# Altium schematic sheet H5_Codec.SchDoc(H5_Codec)
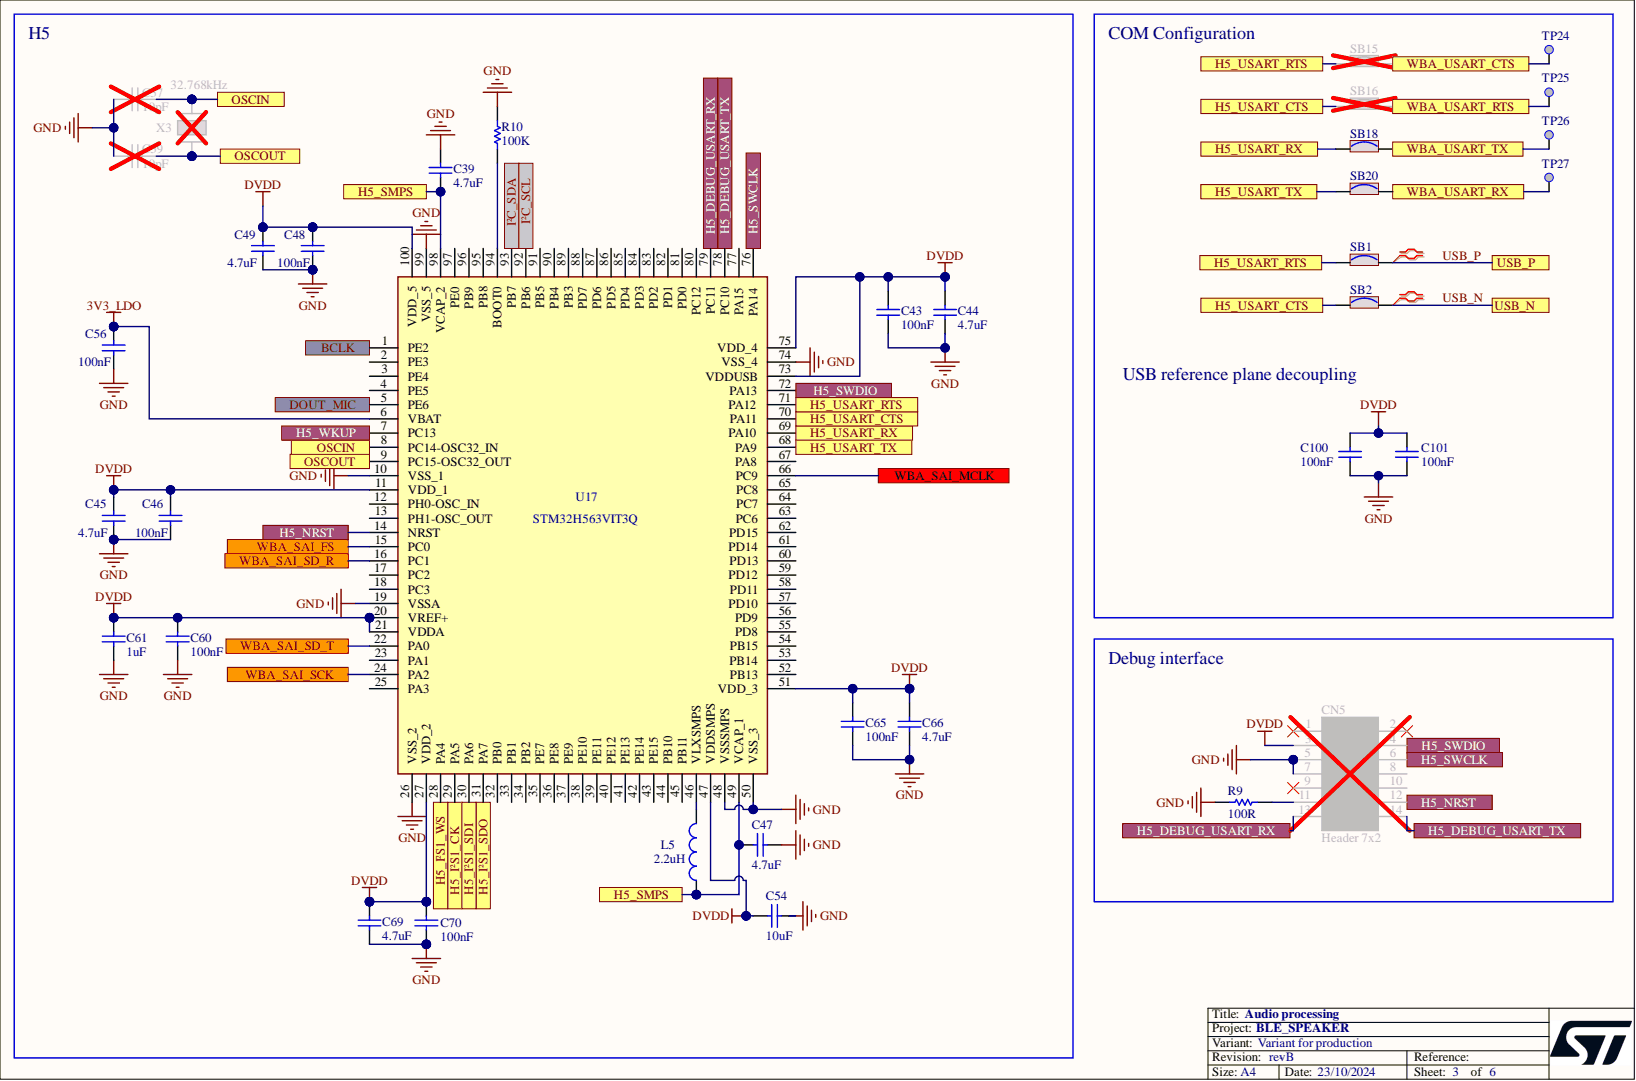
[diagram p0: H5_Codec.SchDoc]

## Parts
C39  value 4.7uF  [C0402]  (hidden comment: Capacitor)
C43  value 100nF  [C0201_H0P3]  (hidden comment: Capacitor)
C44  value 4.7uF  [C0402]  (hidden comment: Capacitor)
C45  value 4.7uF  [C0402]  (hidden comment: Capacitor)
C46  value 100nF  [C0201_H0P3]  (hidden comment: Capacitor)
C47  value 4.7uF  [C0402]  (hidden comment: Capacitor)
C48  value 100nF  [C0201_H0P3]  (hidden comment: Capacitor)
C49  value 4.7uF  [C0402]  (hidden comment: Capacitor)
C54  value 10uF  [C0402]  (hidden comment: Capacitor)
C56  value 100nF  [C0201_H0P3]  (hidden comment: Capacitor)
C57  value 10pF  [C0402]  (hidden comment: Capacitor)
C59  value 10pF  [C0402]  (hidden comment: Capacitor)
C60  value 100nF  [C0201_H0P3]  (hidden comment: Capacitor)
C61  value 1uF  [C0402]  (hidden comment: Capacitor)
C65  value 100nF  [C0201_H0P3]  (hidden comment: Capacitor)
C66  value 4.7uF  [C0402]  (hidden comment: Capacitor)
C69  value 4.7uF  [C0402]  (hidden comment: Capacitor)
C70  value 100nF  [C0201_H0P3]  (hidden comment: Capacitor)
C100  value 100nF  [C0201_H0P3]  (hidden comment: Capacitor)
C101  value 100nF  [C0201_H0P3]  (hidden comment: Capacitor)
CN5  Header 7x2  [CON_STDC14_Receiver_SMALL]
L5  value 2.2uH  [IND_2520_TOKO_DFE252012P]  (hidden comment: Inductor)
R9  value 100R  [R0402]  (hidden comment: Resistor)
R10  value 100K  [R0402]  (hidden comment: Resistor)
SB1  SB_ON  [SOLDER-BRIDGE_R0201_0R]  (value not drawn)
SB2  SB_ON  [SOLDER-BRIDGE_R0201_0R]  (value not drawn)
SB15  SB_ON  [SOLDER-BRIDGE_R0201_0R]  (value not drawn)
SB16  SB_ON  [SOLDER-BRIDGE_R0201_0R]  (value not drawn)
SB18  SB_ON  [SOLDER-BRIDGE_R0201_0R]  (value not drawn)
SB20  SB_ON  [SOLDER-BRIDGE_R0201_0R]  (value not drawn)
TP24  Test Point  [TP_SMD_1MM]  (value not drawn)  (no BOM)
TP25  Test Point  [TP_SMD_1MM]  (value not drawn)  (no BOM)
TP26  Test Point  [TP_SMD_1MM]  (value not drawn)  (no BOM)
TP27  Test Point  [TP_SMD_1MM]  (value not drawn)  (no BOM)
U17  STM32H563VIT3Q  [QFP50P1600X1600X160-100N]
X3  value 32.768kHz  [CRY_NX1610SE_2P]  (hidden comment: XTAL_2_PINS)

## Ports
BCLK, DOUT_MIC, H5_DEBUG_USART_RX, H5_DEBUG_USART_TX, H5_I²S1_CK, H5_I²S1_SDI, H5_I²S1_SDO, H5_I²S1_WS, H5_NRST, H5_SMPS, H5_SWCLK, H5_SWDIO, H5_USART_CTS, H5_USART_RTS, H5_USART_RX, H5_USART_TX, H5_WKUP, I²C_SCL, I²C_SDA, OSCIN, OSCOUT, USB_N, USB_P, WBA_SAI_FS, WBA_SAI_MCLK, WBA_SAI_SCK, WBA_SAI_SD_R, WBA_SAI_SD_T, WBA_USART_CTS, WBA_USART_RTS, WBA_USART_RX, WBA_USART_TX

## Nets
3V3_LDO: C56.1 U17.6(VBAT)
DVDD: C43.2 C44.2 C45.2 C46.2 C48.2 C49.2 C54.1 C60.2 C61.1 C65.2 C66.2 C69.2 C70.2 C100.2 C101.2 CN5.3 U17.11(VDD_1) U17.20(VREF+) U17.21(VDDA) U17.27(VDD_2) U17.47(VDDSMPS) U17.51(VDD_3) U17.73(VDDUSB) U17.75(VDD_4) U17.100(VDD_5)
GND: C39.2 C43.1 C44.1 C45.1 C46.1 C47.2 C48.1 C49.1 C54.2 C56.2 C57.2 C59.2 C60.1 C61.2 C65.1 C66.1 C69.1 C70.1 C100.1 C101.1 CN5.5 CN5.7 R9.1 R10.1 U17.10(VSS_1) U17.19(VSSA) U17.26(VSS_2) U17.48(VSSSMPS) U17.50(VSS_3) U17.74(VSS_4) U17.99(VSS_5)
BCLK: U17.1(PE2) ; port BCLK
DOUT_MIC: U17.5(PE6) ; port DOUT_MIC
H5_DEBUG_USART_RX: CN5.13 U17.79(PC11) ; port H5_DEBUG_USART_RX
H5_DEBUG_USART_TX: CN5.14 U17.78(PC10) ; port H5_DEBUG_USART_TX
H5_I²S1_CK: U17.29(PA5) ; port H5_I²S1_CK
H5_I²S1_SDI: U17.30(PA6) ; port H5_I²S1_SDI
H5_I²S1_SDO: U17.31(PA7) ; port H5_I²S1_SDO
H5_I²S1_WS: U17.28(PA4) ; port H5_I²S1_WS
H5_NRST: CN5.12 U17.14(NRST) ; port H5_NRST
H5_SMPS: C39.1 C47.1 L5.1 U17.49(VCAP_1) U17.98(VCAP_2) ; port H5_SMPS
H5_SWCLK: CN5.6 U17.76(PA14) ; port H5_SWCLK
H5_SWDIO: CN5.4 U17.72(PA13) ; port H5_SWDIO
H5_USART_CTS: SB2.2 SB16.2 U17.70(PA11) ; port H5_USART_CTS
H5_USART_RTS: SB1.2 SB15.2 U17.71(PA12) ; port H5_USART_RTS
H5_USART_RX: SB18.2 U17.69(PA10) ; port H5_USART_RX
H5_USART_TX: SB20.2 U17.68(PA9) ; port H5_USART_TX
H5_WKUP: U17.7(PC13) ; port H5_WKUP
I²C_SCL: U17.92(PB6) ; port I²C_SCL
I²C_SDA: U17.93(PB7) ; port I²C_SDA
OSCIN: C57.1 U17.8(PC14-OSC32_IN) X3.1 ; port OSCIN
OSCOUT: C59.1 U17.9(PC15-OSC32_OUT) X3.2 ; port OSCOUT
USB_N: SB2.1 ; port USB_N
USB_P: SB1.1 ; port USB_P
WBA_SAI_FS: U17.15(PC0) ; port WBA_SAI_FS
WBA_SAI_MCLK: U17.66(PC9) ; port WBA_SAI_MCLK
WBA_SAI_SCK: U17.24(PA2) ; port WBA_SAI_SCK
WBA_SAI_SD_R: U17.16(PC1) ; port WBA_SAI_SD_R
WBA_SAI_SD_T: U17.22(PA0) ; port WBA_SAI_SD_T
WBA_USART_CTS: SB15.1 TP24.1 ; port WBA_USART_CTS
WBA_USART_RTS: SB16.1 TP25.1 ; port WBA_USART_RTS
WBA_USART_RX: SB20.1 TP27.1 ; port WBA_USART_RX
WBA_USART_TX: SB18.1 TP26.1 ; port WBA_USART_TX
NetCN5_11: CN5.11 R9.2
NetL5_2: L5.2 U17.46(VLXSMPS)
NetR10_2: R10.2 U17.94(BOOT0)

Not connected: CN5 1 2 8 9 10; U17 2(PE3) 3(PE4) 4(PE5) 12(PH0-OSC_IN) 13(PH1-OSC_OUT) 17(PC2) 18(PC3) 23(PA1) 25(PA3) 32(PB0) 33(PB1) 34(PB2) 35(PE7) 36(PE8) 37(PE9) 38(PE10) 39(PE11) 40(PE12) 41(PE13) 42(PE14) 43(PE15) 44(PB10) 45(PB11) 52(PB13) 53(PB14) 54(PB15) 55(PD8) 56(PD9) 57(PD10) 58(PD11) 59(PD12) 60(PD13) 61(PD14) 62(PD15) 63(PC6) 64(PC7) 65(PC8) 67(PA8) 77(PA15) 80(PC12) 81(PD0) 82(PD1) 83(PD2) 84(PD3) 85(PD4) 86(PD5) 87(PD6) 88(PD7) 89(PB3) 90(PB4) 91(PB5) 95(PB8) 96(PB9) 97(PE0)

## Library data of the parts
C39 C44 C45 C47 C49 C66 C69 = MCD-01356: Capacitor, MLCC, 4.7uF, 6V3, X5R, +/-20%, 0402, T=0.5mm; Manufacturer: MURATA; Manufacturer_Part_Number: GRM155R60J475ME47; Part_Preference: Recommended; Component_Country_Of_Origin: Japan°°; Database Table Name: MCD_PARTS$; ID: 3456; Order_Code_RS: 814-5230; ShowFields: YES; Component Kind: Standard; ComponentLink1URL: http://tunmcdsvn.tun.st.com/Component_Documentation_Library/Trunk/Capacitor/MURATA_GRM_Series; Internal_Part_Number: MCD-01356; Library Name: MCD_PARTS_database.DbLib; Order_Code_Digikey: 490-5915-1-ND; Order_Code_Farnell: 2666407; Package: 0402; Part_Type: Capacitor; Status: Released
C43 C46 C48 C56 C60 C65 C70 C100 C101 = MCD-00697: Capacitor, MLCC, 100nF,10V, X5R, +/-10%, 0201, T=0.3mm; Manufacturer: TDK; Manufacturer_Part_Number: C0603X5R1A104K030BC; Part_Preference: Recommended; Component_Country_Of_Origin: Unknown; Database Table Name: MCD_PARTS$; ID: 3046; ShowFields: YES; Component Kind: Standard; ComponentLink1URL: http://tunmcdsvn.tun.st.com/Component_Documentation_Library/Trunk/Capacitor/TDK_MCC_Series; Internal_Part_Number: MCD-00697; Library Name: MCD_PARTS_database.DbLib; Order_Code_Digikey: 445-7318-1-ND; Order_Code_Farnell: 2524957; Order_Code_RS: N/A; Package: 0201; Part_Type: Capacitor; Status: Released
C54 = MCD-03140: Capacitor, MLCC, 10uF, 6.3V, X5R, +/-20%, 0402, T=0.5mm; Manufacturer: MURATA; Manufacturer_Part_Number: GRM155R60J106ME05D; Part_Preference: Recommended; Component_Country_Of_Origin: China, Japan; Database Table Name: MCD_PARTS$; ID: 5256; ShowFields: YES; Component Kind: Standard; ComponentLink1URL: http://tunmcdsvn.tun.st.com/Component_Documentation_Library/Trunk/Capacitor/MURATA_GRM_Series; Internal_Part_Number: MCD-03140; Library Name: MCD_PARTS_database.DbLib; Order_Code_Digikey: 490-GRM155R60J106ME05DTR-ND; Order_Code_Farnell: 3784428; Order_Code_RS: N/A; Package: 0402; Part_Type: Capacitor; Status: Released
C57 C59 = MCD-00015: Capacitor, MLCC, 10pF, 50V, NPO, +/-5%, 0402, T=0.5mm; Manufacturer: YAGEO; Manufacturer_Part_Number: CC0402JRNPO9BN100; Part_Preference: Recommended; Component_Country_Of_Origin: China; Database Table Name: MCD_PARTS$; ID: 2465; Order_Code_RS: 3019160RL; ShowFields: YES; Component Kind: Standard; ComponentLink1URL: http://tunmcdsvn.tun.st.com/Component_Documentation_Library/Trunk/Capacitor/YAGEO_NP0_Series; Internal_Part_Number: MCD-00015; Library Name: MCD_PARTS_database.DbLib; Order_Code_Digikey: 311-1014-1-ND; Order_Code_Farnell: 3019160RL; Package: 0402; Part_Type: Capacitor; Status: Released
C61 = MCD-01722: Capacitor, MLCC, 1uF, 10V, X5R, +/-10%, 0402, T=0.5mm; Manufacturer: YAGEO; Manufacturer_Part_Number: CC0402KRX5R6BB105; Part_Preference: Recommended; Component_Country_Of_Origin: China; Database Table Name: MCD_PARTS$; ID: 3822; ShowFields: YES; Component Kind: Standard; ComponentLink1URL: http://tunmcdsvn.tun.st.com/Component_Documentation_Library/Trunk/Capacitor/YAGEO_X5R_Series; Internal_Part_Number: MCD-01722; Library Name: MCD_PARTS_database.DbLib; Order_Code_Digikey: 311-1439-1-ND; Order_Code_Farnell: 1458896; Order_Code_RS: N/A; Package: 0402; Part_Type: Capacitor; Status: Released
CN5 = MCD-00639: Header, 7x2, 1.27mm, VR, SMD, T=6.12mm; Manufacturer: SAMTEC; Manufacturer_Part_Number: FTSH-107-01-L-DV-K; Part_Preference: Recommended; Part_Type: Connector; Partial_Alternate_Internal_Part_Number: MCD-03872; Component_Country_Of_Origin: China, Costa Rica, Malaysia, USA, Vietnam; Database Table Name: MCD_PARTS$; ID: 3107; Order_Code_RS: 179-8765; ShowFields: YES; Component Kind: Standard; ComponentLink1URL: http://tunmcdsvn.tun.st.com/Component_Documentation_Library/Trunk/Connector/SAMTEC_FTSH_Series/; Internal_Part_Number: MCD-00639; Library Name: MCD_PARTS_database.DbLib; Order_Code_Digikey: SAM9449-ND; Order_Code_Farnell: 2856443; Status: Released; Value: Header 7x2
L5 = MCD-00161: Inductor, 2.2uH, +/-20%, 2,2A, 84mR, 1008, T=1.2mm; Manufacturer: TOKO; Manufacturer_Part_Number: DFE252012P-2R2M=P2; Part_Preference: Recommended; Component_Country_Of_Origin: Japan°°; Database Table Name: MCD_PARTS$; ID: 2711; Order_Code_RS: 167-0606; ShowFields: YES; Component Kind: Standard; ComponentLink1URL: http://tunmcdsvn.tun.st.com/Component_Documentation_Library/Trunk/Inductor/TOKO_DFE252012P_Series; Internal_Part_Number: MCD-00161; Library Name: MCD_PARTS_database.DbLib; Order_Code_Digikey: 490-10649-1-ND; Order_Code_Farnell: 2530074; Package: 1008; Part_Type: Inductor; Status: Checked
R9 = MCD-00119: Resistor, 100R, 0402, +/-1%, 1/16W; Manufacturer: YAGEO; Manufacturer_Part_Number: RC0402FR-07100RL; Part_Preference: Not recommended; Component_Country_Of_Origin: China; Database Table Name: MCD_PARTS$; ID: 2748; ShowFields: YES; Component Kind: Standard; ComponentLink1URL: http://tunmcdsvn.tun.st.com/Component_Documentation_Library/Trunk/Resistor/YAGEO_RC_L_Series; Internal_Part_Number: MCD-00119; Library Name: MCD_PARTS_database.DbLib; Order_Code_Digikey: 311-100LRCT-ND; Order_Code_Farnell: 9239111; Order_Code_RS: N/A; Package: 0402; Part_Type: Resistor; Status: Released
R10 = MCD-00059: Resistor, 100K, 0402, +/-1%, 1/16W; Manufacturer: YAGEO; Manufacturer_Part_Number: RC0402FR-07100KL; Part_Preference: Recommended; Partial_Alternate_Internal_Part_Number: MCD-03301; Component_Country_Of_Origin: China; Database Table Name: MCD_PARTS$; ID: 2533; Order_Code_RS: 2421891; ShowFields: YES; Component Kind: Standard; ComponentLink1URL: http://tunmcdsvn.tun.st.com/Component_Documentation_Library/Trunk/Resistor/YAGEO_RC_L_Series; Internal_Part_Number: MCD-00059; Library Name: MCD_PARTS_database.DbLib; Order_Code_Digikey: 311-100KLRTR-ND; Order_Code_Farnell: 2421891; Package: 0402; Part_Type: Resistor; Status: Released
SB1 SB2 SB15 SB16 SB18 SB20 = MCD-03219: Solder Bridge ON, 0R, 0201, 1/20W; Manufacturer: YAGEO; Manufacturer_Part_Number: RC0201JR-070RL; Part_Preference: Recommended; Part_Type: Misc; Component_Country_Of_Origin: China; Database Table Name: MCD_PARTS$; ID: 5335; Order_Code_RS: 198-7623; ShowFields: YES; Component Kind: Standard; ComponentLink1URL: http://tunmcdsvn.tun.st.com/Component_Documentation_Library/Trunk/Resistor/YAGEO_RC_L_Series; Internal_Part_Number: MCD-03219; Library Name: MCD_PARTS_database.DbLib; Order_Code_Digikey: 311-0.0NTR-ND; Order_Code_Farnell: 1458895; Status: Checked; Value: 0R
  SB1: Package: 0201
  SB2 SB15 SB16 SB18 SB20: Package: SB0201
TP24-TP27 = MCD-00074: Test Point 1mm; Part_Preference: Recommended; Part_Type: Misc; Component_Country_Of_Origin: Unknown; Database Table Name: MCD_PARTS$; ID: 2518; ShowFields: YES; ComponentLink1URL: http://tunmcdsvn.tun.st.com/Component_Documentation_Library/Trunk/Misc/; Internal_Part_Number: MCD-00074; Library Name: MCD_PARTS_database.DbLib; Manufacturer: N/A; Manufacturer_HQ_COO: Unknown; Manufacturer_Part_Number: N/A; Order_Code_Digikey: N/A; Order_Code_Farnell: N/A; Order_Code_RS: N/A; Status: Released; Value: Test Point 1mm
U17 = STM32H563VIT3Q: ARM Microcontrollers - MCU Arm Cortex-M33 32-bit 250 MHz 2 Mbytes Flash 640KB RAM I3C, DCMI, Ethernet, SMPS; Manufacturer_Name: STMicroelectronics; Geometry.Height: 1.6mm; Component Kind: Standard; Datasheet Link: https://www.st.com/resource/en/datasheet/stm32h562ag.pdf; Library Name: STM32H563VIT3Q.SchLib; Manufacturer_Part_Number: STM32H563VIT3Q; Mouser Part Number: 511-STM32H563VIT3Q; Mouser Price/Stock: https://www.mouser.co.uk/ProductDetail/STMicroelectronics/STM32H563VIT3Q?qs=amGC7iS6iy8I0QD381YFuQ%3D%3D
X3 = MCD-02291: Crystal, 32.768kHz, 9pF, +/-20ppm, 70KR, 1.6x1mm; Manufacturer: NDK; Manufacturer_Part_Number: NX1610SE-32.768KHZ-EXS00A-MU01499; Part_Preference: Recommended; Part_Type: Crystal_Osc; Component_Country_Of_Origin: Unknown; Database Table Name: MCD_PARTS$; ID: 4391; ShowFields: YES; Component Kind: Standard; ComponentLink1URL: http://tunmcdsvn.tun.st.com/Component_Documentation_Library/Trunk/Crystal_Oscillator/NDK_NX1610SE_Series; Internal_Part_Number: MCD-02291; Library Name: MCD_PARTS_database.DbLib; Order_Code_Digikey: N/A; Order_Code_Farnell: N/A; Order_Code_RS: N/A; Package: 1.6x1mm; Status: Released
